# Altium schematic sheet Modbus RTU.SchDoc("Modbus RTU")
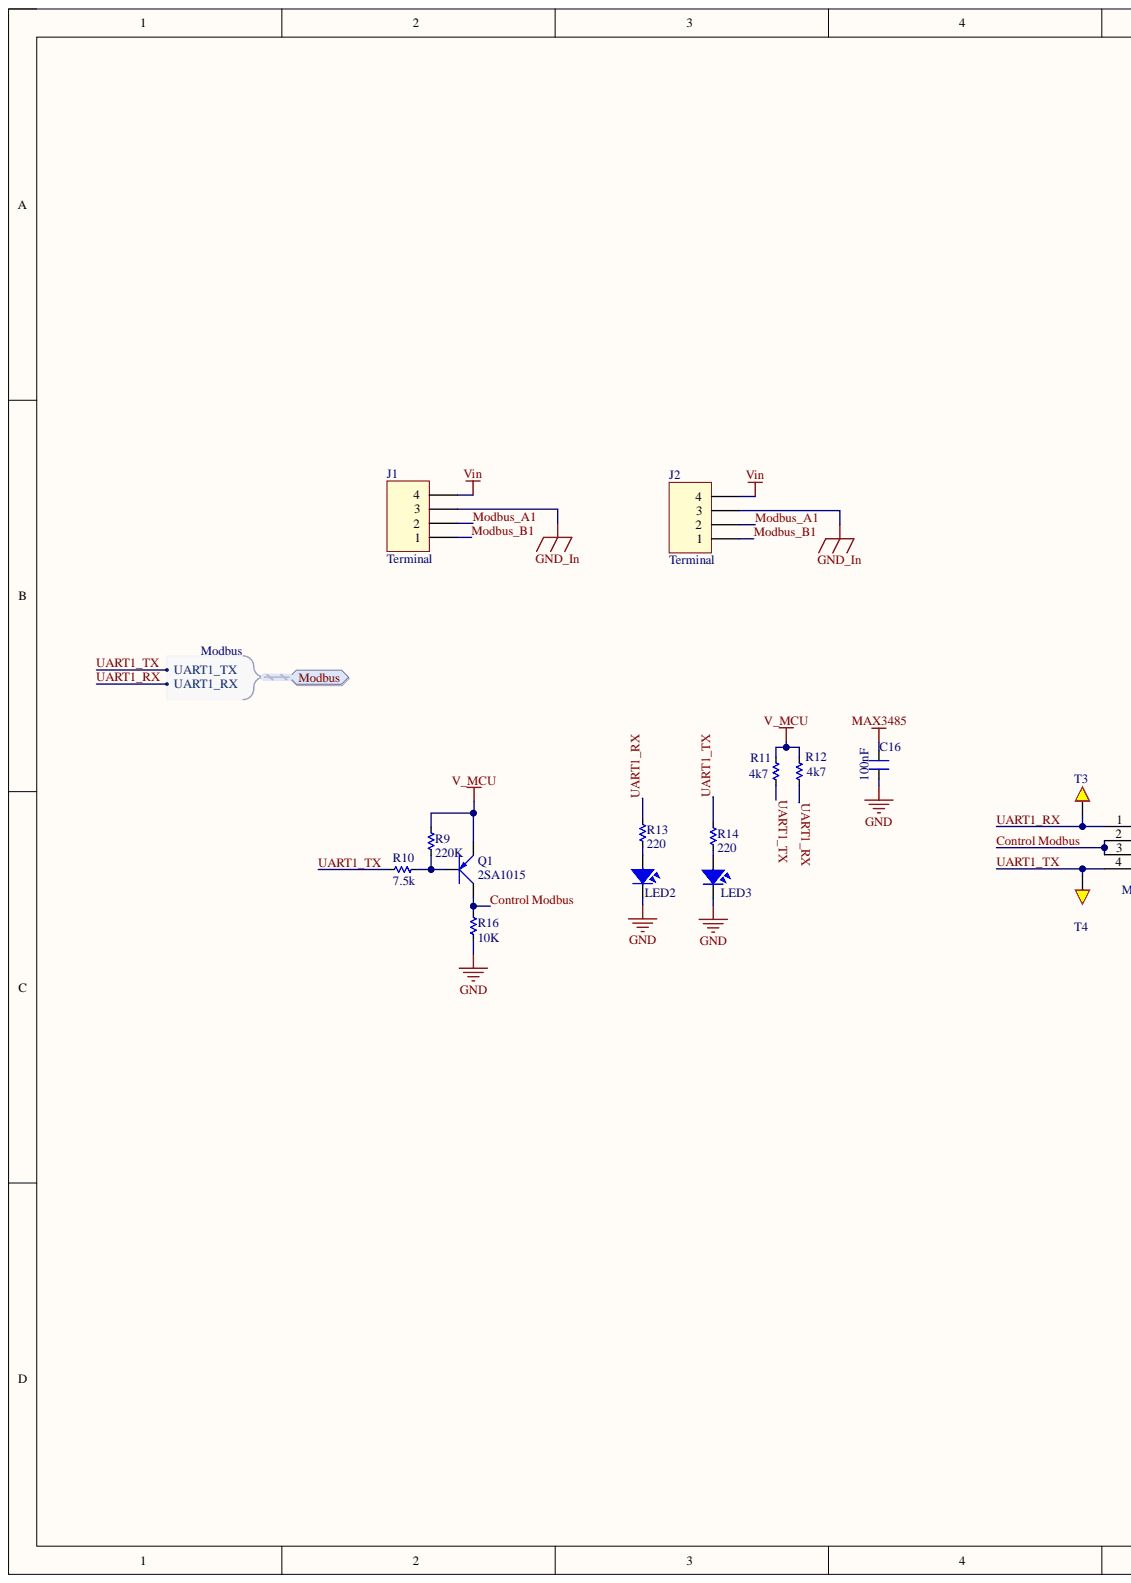
[diagram p0: Modbus RTU.SchDoc, left]
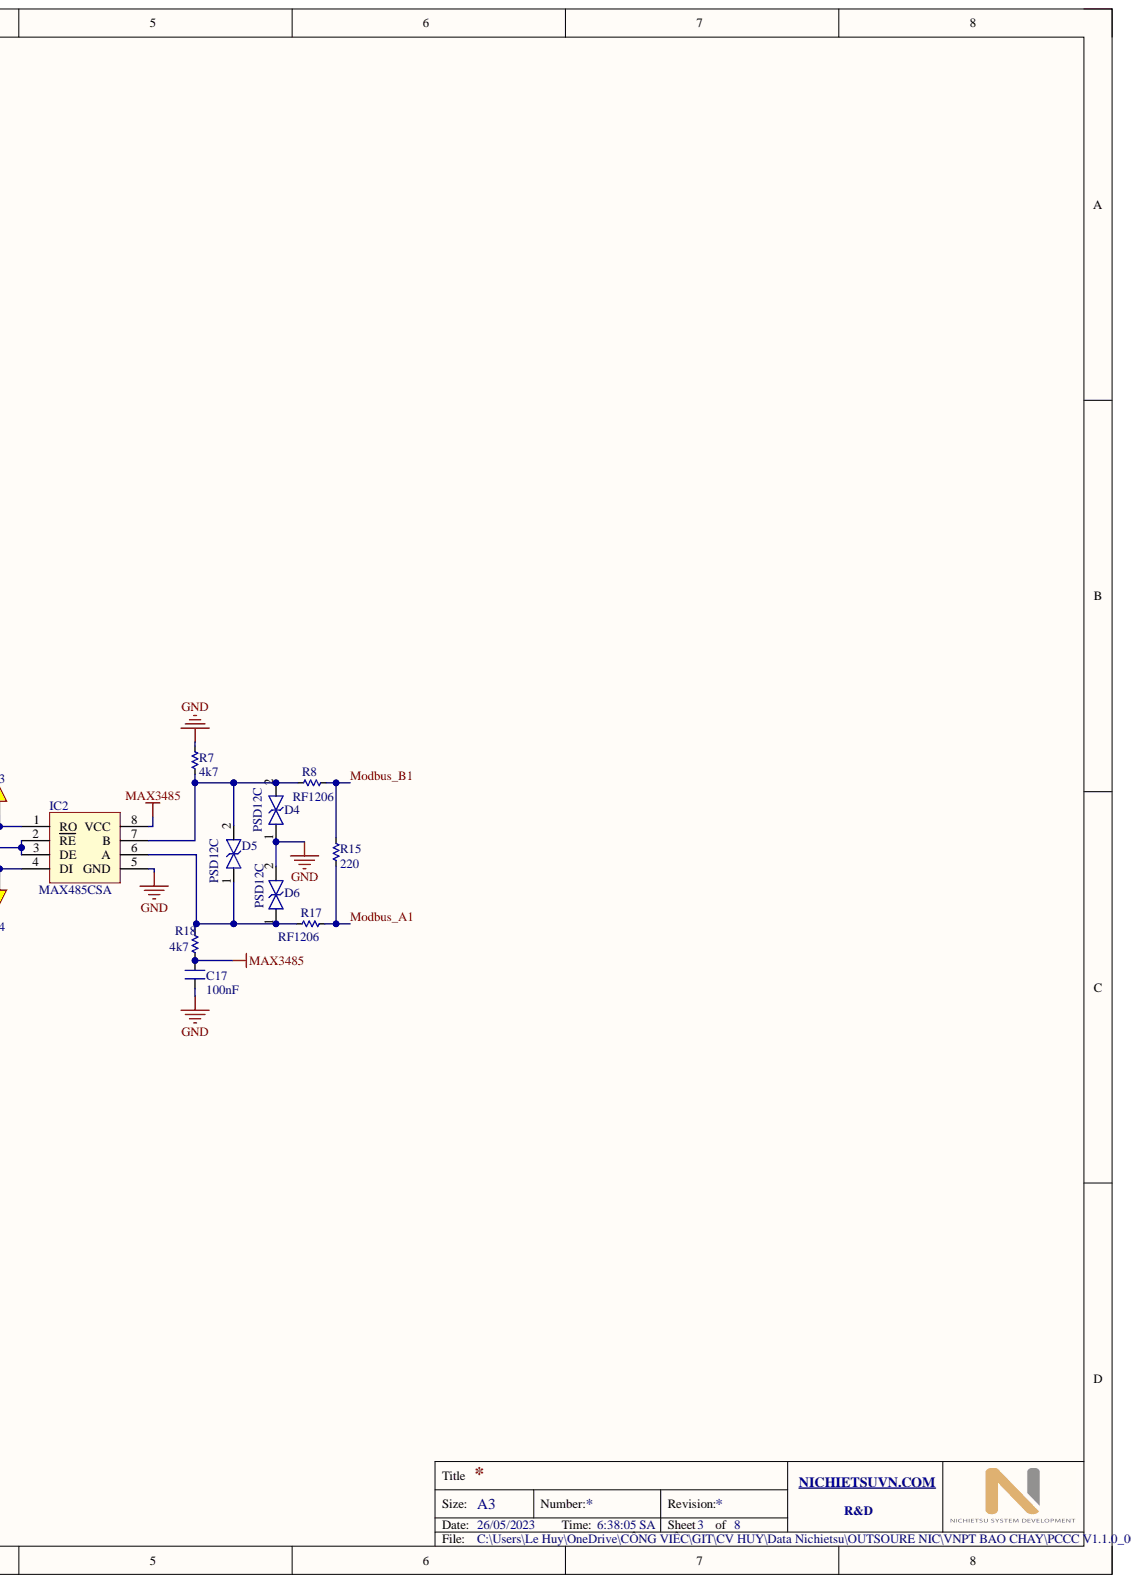
[diagram p1: Modbus RTU.SchDoc, right]

## Parts
C16  100nF  [CN-SMD-0603]
C17  100nF  [CN-SMD-0603]
D4  PSD12C  [PSD12C]
D5  PSD12C  [PSD12C]
D6  PSD12C  [PSD12C]
IC2  MAX485CSA  [SO8]
J1  Terminal  [691305340004]
J2  Terminal  [691305340004]
LED2  LED  [LED-0805-Blue]
LED3  LED  [LED-0805-Blue]
Q1  2SA1015  [SOT23-321]
R7  4k7  [RES-SMD-0603]
R8  RF1206  [RES-SMD-1206]
R9  220K  [RES-SMD-0603]
R10  7.5k  [RES-SMD-0603]
R11  4k7  [RES-SMD-0603]
R12  4k7  [RES-SMD-0603]
R13  220  [RES-SMD-0603]
R14  220  [RES-SMD-0603]
R15  220  [RES-SMD-0603]
R16  10K  [RES-SMD-0603]
R17  RF1206  [RES-SMD-1206]
R18  4k7  [RES-SMD-0603]
T3  Test  [Test]  (value not drawn)
T4  Test  [Test]  (value not drawn)

## Ports
Modbus, Modbus.UART1_RX, Modbus.UART1_TX

## Nets
GND: C16.1 C17.2 D4.1 D6.2 IC2.5(GND) LED2.2 LED3.2 R7.1 R16.1
GND_In: J1.3 J2.3
MAX3485: C16.2 C17.1 IC2.8(VCC) R18.1
V_MCU: Q1.1 R9.2 R11.1 R12.1
Vin: J1.4 J2.4
Control Modbus: IC2.2(RE) IC2.3(DE) Q1.2 R16.2
Modbus_A1: J1.2 J2.2 R15.2 R17.1
Modbus_B1: J1.1 J2.1 R8.1 R15.1
UART1_RX: IC2.1(RO) R12.2 R13.1 T3.1 ; port Modbus
UART1_TX: IC2.4(DI) R10.1 R11.2 R14.1 T4.1 ; port Modbus
NetD4_2: D4.2 D5.2 IC2.7(B) R7.2 R8.2
NetD5_1: D5.1 D6.1 IC2.6(A) R17.2 R18.2
NetLED2_1: LED2.1 R13.2
NetLED3_1: LED3.1 R14.2
NetQ1_3: Q1.3 R9.1 R10.2

## Library data of the parts
C16 C17 = CN: Value: 33pF; Component Kind: Standard; Library Name: thu vien 3d.IntLib
D4-D6 = PSD12C: diode tvs; Component Kind: Standard; Library Name: Nichietsuvn.SchLib
IC2 = MAX485: RS232 - RS485 (Same sn75176); Component Kind: Standard; Library Name: thu vien 3d.IntLib
J1 J2 = 691305340004: WR-TBL Serie 3053 - 3.81 mm Horizontal PCB Header with Plastic Latch, 04p; Pitch: 3.81 mm; Component Kind: Standard; ComponentLink1Description: Manufacturer; ComponentLink1URL: http://www.we-online.de; ComponentLink2Description: Datasheet; ComponentLink2URL: http://katalog.we-online.de/em/datasheet/691305340004.pdf; Library Name: header 3.81.IntLib; Part Number: 691305340004; Pin Number: 04p; Published Date: Jan 2017; Publisher: Wurth Elektronik
LED2 LED3 = LED SMD: Value: LED 3 - 5 ly 2 Colour; Component Kind: Standard; Library Name: thu vien 3d.IntLib
Q1 = 2SA1015: PNP 600mW, ECB; Value: A1015; Component Kind: Standard; Library Name: thu vien 3d.IntLib
R7-R18 = Res-SMD: Resistor; Manufacturer: Generic Components; Class: Passive; Component Kind: Standard; Library Name: thu vien 3d.IntLib; Published: 08/06/2000; Publisher: Altium Hobart Technology Centre
T3 T4 = Test: WR-PHD 2.54 mm Angled Socket Header; Manufacturer: W¨¹rth Elektronik eiSos GmbH; partNo: 613002143121; ComponentLink1 Description: Manufacturer; ComponentLink2 Description: Datasheet; NoPins: 02; Component Kind: Standard; ComponentLink1URL: http://www.we-online.com; ComponentLink2URL: http://katalog.we-online.de/em/datasheet/6130xx143121.pdf; Created Date: 29 March 2013; Created by: Karrer Zheng; Library Name: Nichietsuvn.SchLib
